# Altium schematic sheet ANALOG_INPUT.SchDoc(ANALOG_INPUT)
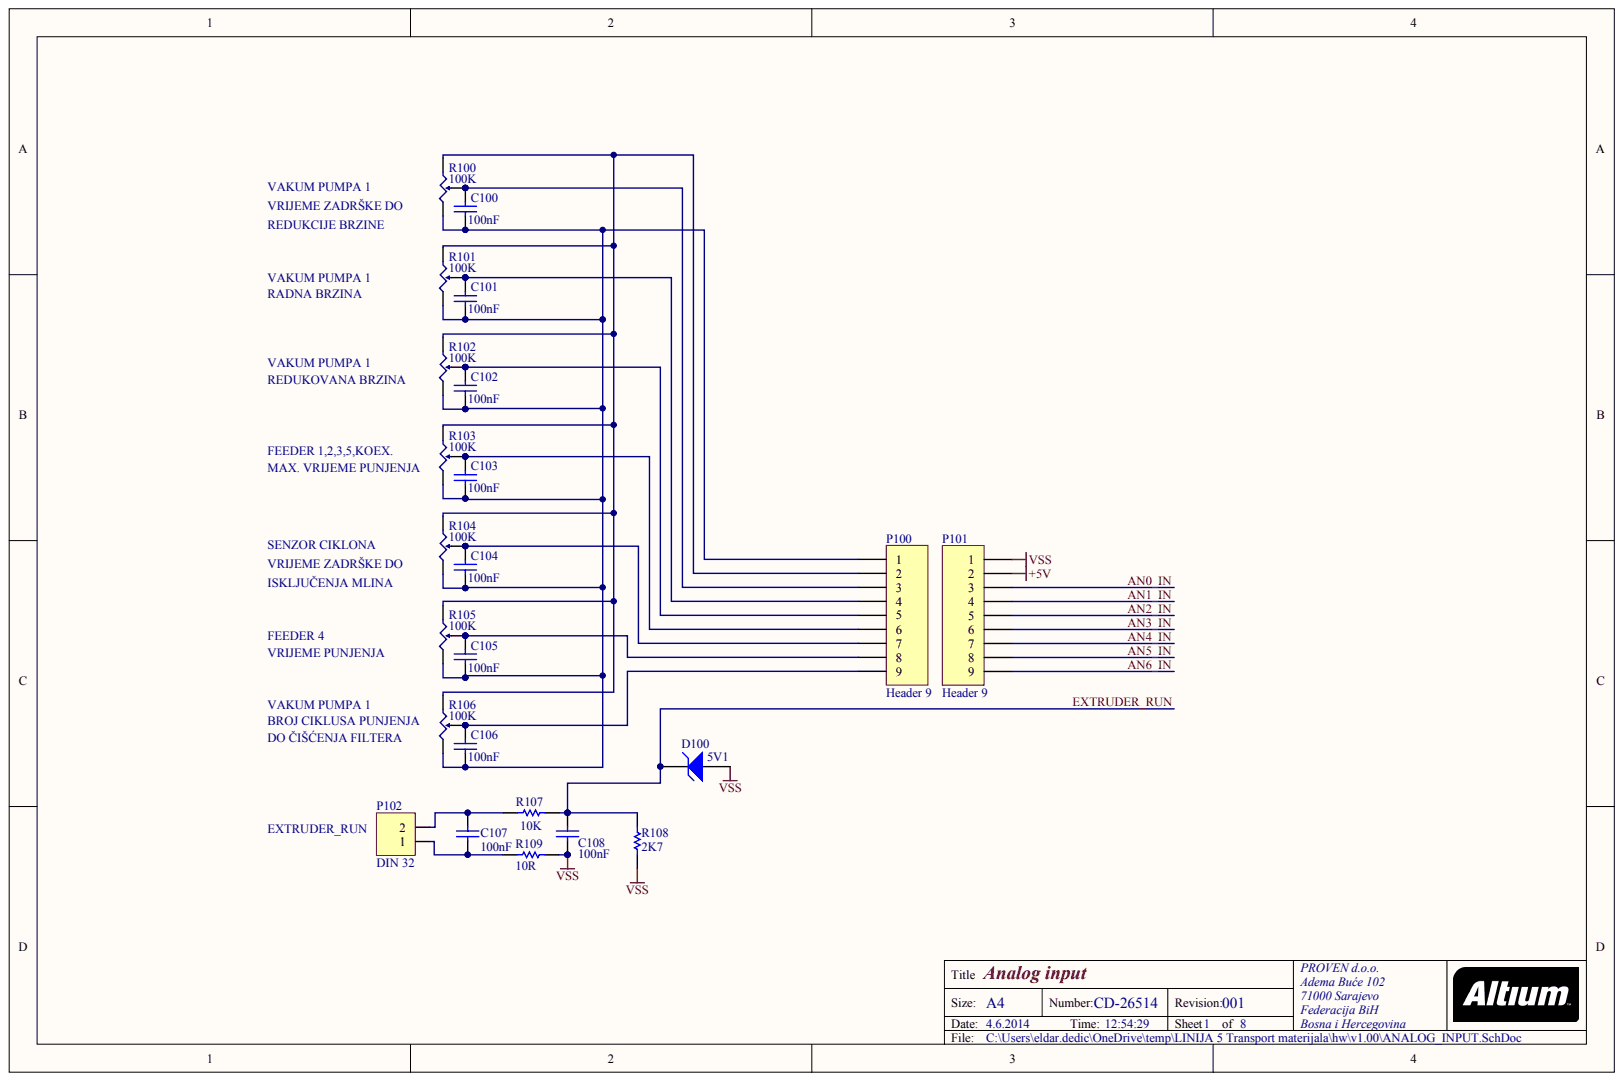
[diagram p0: ANALOG_INPUT.SchDoc]

## Parts
C100  value 100nF
C101  value 100nF
C102  value 100nF
C103  value 100nF
C104  value 100nF
C105  value 100nF
C106  value 100nF
C107  value 100nF
C108  value 100nF
D100  5V1
P100  Header 9
P101  Header 9
P102  DIN 32
R100  100K
R101  100K
R102  100K
R103  100K
R104  100K
R105  100K
R106  100K
R107  10K
R108  2K7
R109  10R

## Nets
+5V: P101.2
VSS: C108.2 D100.1 P101.1 R108.2 R109.1
AN0_IN: P101.3
AN1_IN: P101.4
AN2_IN: P101.5
AN3_IN: P101.6
AN4_IN: P101.7
AN5_IN: P101.8
AN6_IN: P101.9
EXTRUDER_RUN: C108.1 D100.2 R107.1 R108.1
NetC100_1: C100.1 C101.1 C102.1 C103.1 C104.1 C105.1 C106.1 P100.1 R100.2 R101.2 R102.2 R103.2 R104.2 R105.2 R106.2
NetC100_2: C100.2 P100.3 R100.3
NetC101_2: C101.2 P100.4 R101.3
NetC102_2: C102.2 P100.5 R102.3
NetC103_2: C103.2 P100.6 R103.3
NetC104_2: C104.2 P100.7 R104.3
NetC105_2: C105.2 P100.8 R105.3
NetC106_2: C106.2 P100.9 R106.3
NetC107_1: C107.1 P102.2 R107.2
NetC107_2: C107.2 P102.1 R109.2
NetP100_2: P100.2 R100.1 R101.1 R102.1 R103.1 R104.1 R105.1 R106.1

## Library data of the parts
R107-R109 = (no symbol name): Note: If flow soldering, adjust pad width of PCB Footprint to 0.7 - 0.8 times the width of the resistor.; Published: 17-Dec-2003; LatestRevisionDate: 19-Mar-2004; LatestRevisionNote: Silkscreen size reduced.; PackageDocument: Mar-2003; PackageReference: ERA-0805; Code_IPC: 2012 [0805]; ComponentLink1Description: Manufacturer Link; ComponentLink1URL: http://www.panasonic.com/industrial/components/resistive_products.htm; ComponentLink2Description: Datasheet; ComponentLink2URL: http://www.panasonic.com/industrial/components/pdf/AOA0000CE8.pdf; Publisher: Altium Limited
C100-C108 D100 P100-P102 R100-R106 = (no symbol name)
  C100-C108: Published: 17-Jul-2002; LatestRevisionDate: 19-Mar-2004; LatestRevisionNote: Silkscreen size reduced.; DatasheetDocument: Mar-2001; PackageDocument: Mar-2001; PackageReference: 1206; Code_JEITA: 1206; Code_IPC: 3216 [1206]; ComponentLink1Description: Manufacturer Link; ComponentLink1URL: http://www.kemet.com/; ComponentLink2Description: Datasheet; ComponentLink2URL: http://www.kemet.com/kemet/web/homepage/kechome.nsf/vapubfilesname/F3102TA.pdf/$file/F3102TA.pdf
  D100: Published: 8-Jun-2000; LatestRevisionDate: 17-Jul-2002; LatestRevisionNote: Re-released for DXP Platform.; PackageReference: DIODE-0.7; PackageDescription: Diode, Thru-Hole; 2 Leads; 0.7 in Pin Spacing
  P100 P101: LatestRevisionDate: 17-Jul-2002; LatestRevisionNote: Re-released for DXP Platform.
  C100-C108 D100 P100 P101: Publisher: Altium Limited
